# Altium schematic sheet Output_Terminals.SchDoc("Output terminals")
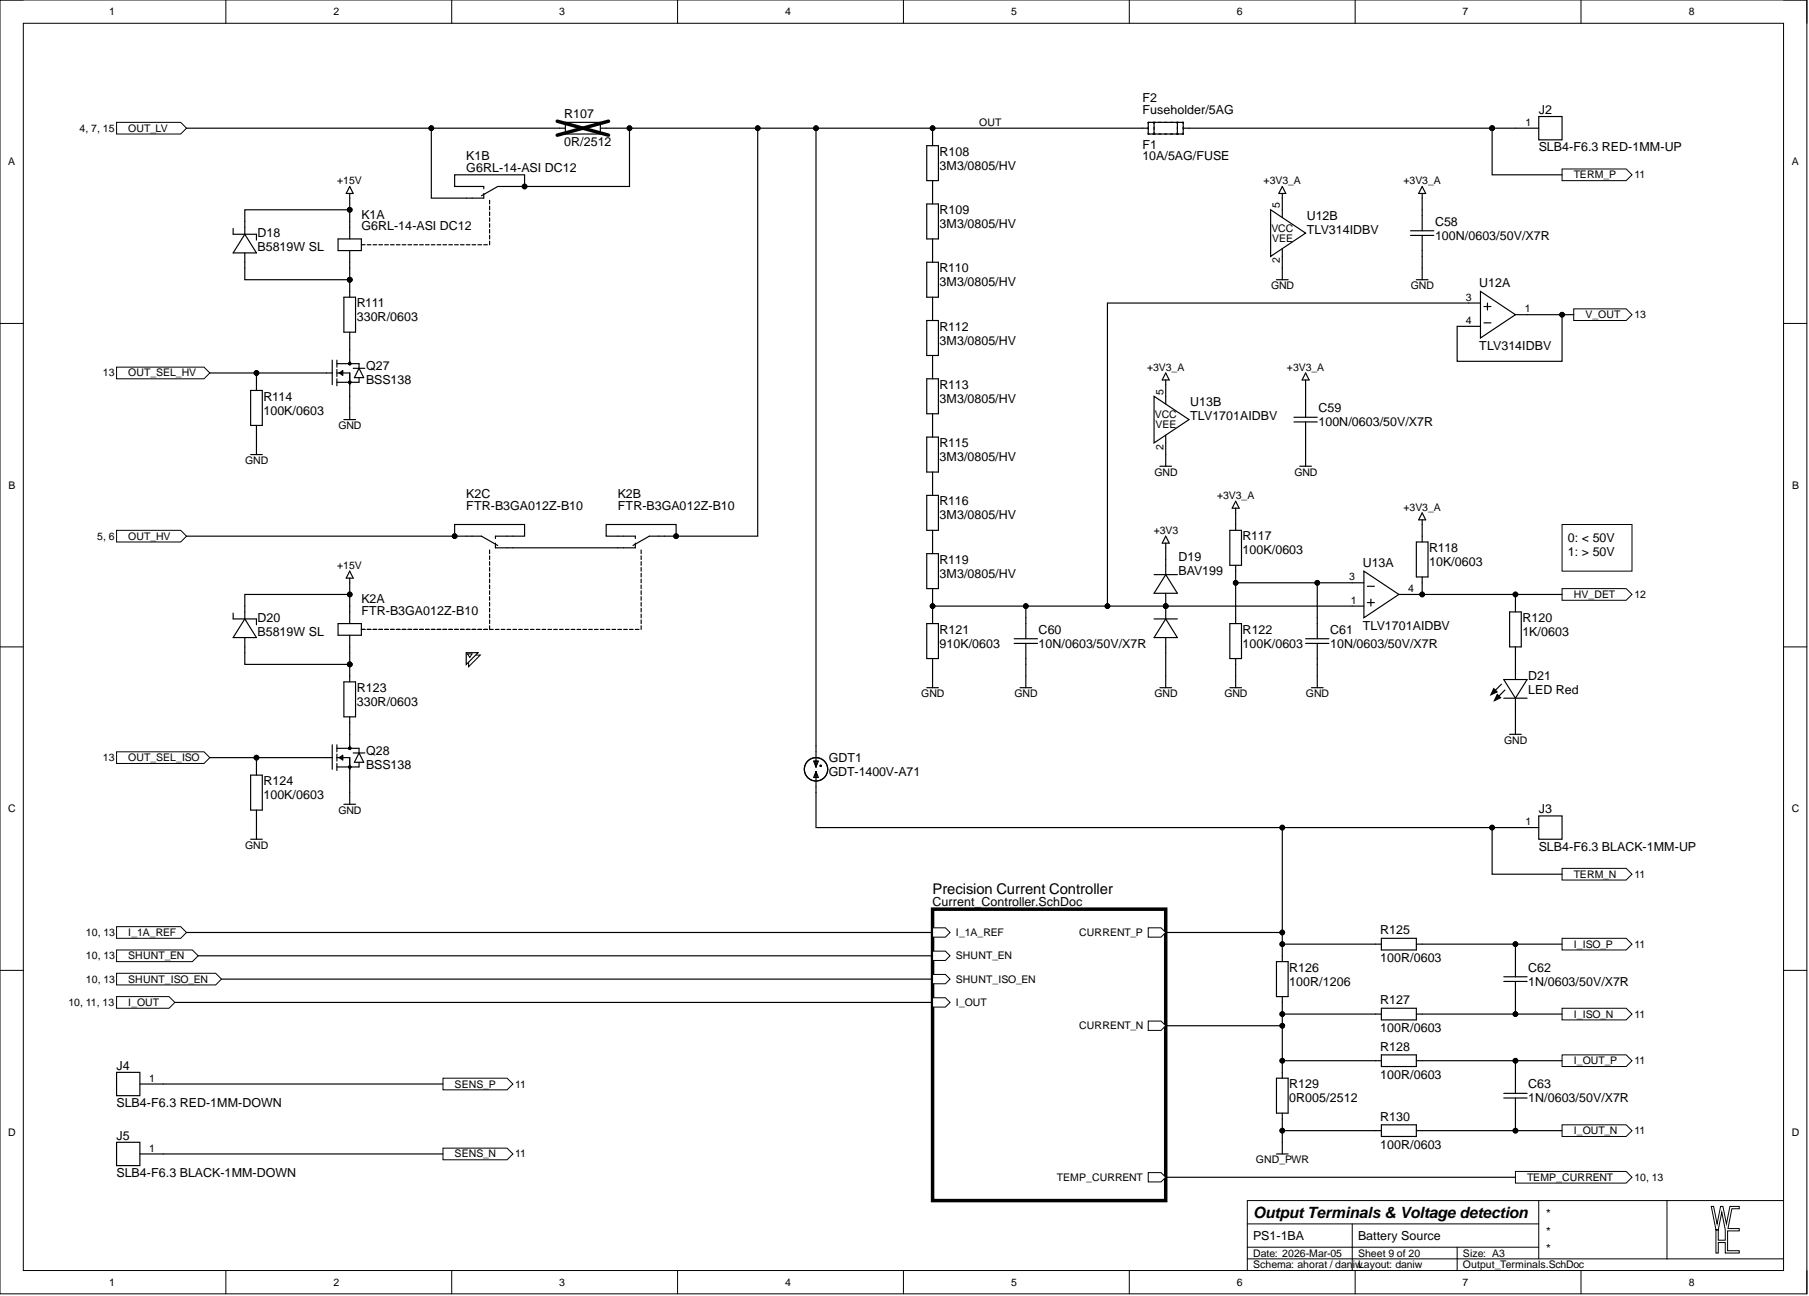
[diagram p0: Output_Terminals.SchDoc]

## Parts
C58  100N/0603/50V/X7R  [CAP0603_X7R]
C59  100N/0603/50V/X7R  [CAP0603_X7R]
C60  10N/0603/50V/X7R  [CAP0603_X7R]
C61  10N/0603/50V/X7R  [CAP0603_X7R]
C62  1N/0603/50V/X7R  [CAP0603_X7R]
C63  1N/0603/50V/X7R  [CAP0603_X7R]
D18  B5819W SL  [SOD3718X125]
D19  BAV199  [SOT2395P280X125-3-SE]
D20  B5819W SL  [SOD3718X125]
D21  LED Red  value Red  Absolute Value: Red  [LED0805_RED]
F1  10A/5AG/FUSE  [Fuse_5AG_5mm]
F2  Fuseholder/5AG  [KEYSTONE_3576]
GDT1  GDT-1400V-A71  [GDTAD1200W100L800D800H1200]
J2  SLB4-F6.3 RED-1MM-UP  [SLB4-F6.3-RED]
J3  SLB4-F6.3 BLACK-1MM-UP  [SLB4-F6.3-BLACK]
J4  SLB4-F6.3 RED-1MM-DOWN  [SLB4-F6.3-RED]
J5  SLB4-F6.3 BLACK-1MM-DOWN  [SLB4-F6.3-BLACK]
K1  G6RL-14-ASI DC12  [G6RL-1]  (parts A,B)
K2  FTR-B3GA012Z-B10  [FTR-B3G]  (parts A,B,C)
Q27  BSS138  [SOT2395P280X125-3-SE]
Q28  BSS138  [SOT2395P280X125-3-SE]
R107  0R/2512  [R2512-0.65-WW]
R108  3M3/0805/HV  [R0805-0.50-HV-WW]
R109  3M3/0805/HV  [R0805-0.50-HV-WW]
R110  3M3/0805/HV  [R0805-0.50-HV-WW]
R111  330R/0603  [R0603-0.40-WW]
R112  3M3/0805/HV  [R0805-0.50-HV-WW]
R113  3M3/0805/HV  [R0805-0.50-HV-WW]
R114  100K/0603  [R0603-0.40-WW]
R115  3M3/0805/HV  [R0805-0.50-HV-WW]
R116  3M3/0805/HV  [R0805-0.50-HV-WW]
R117  100K/0603  [R0603-0.40-WW]
R118  10K/0603  [R0603-0.40-WW]
R119  3M3/0805/HV  [R0805-0.50-HV-WW]
R120  1K/0603  [R0603-0.40-WW]
R121  910K/0603  [R0603-0.40-WW]
R122  100K/0603  [R0603-0.40-WW]
R123  330R/0603  [R0603-0.40-WW]
R124  100K/0603  [R0603-0.40-WW]
R125  100R/0603  [R0603-0.40-WW]
R126  100R/1206  [R1206-0.80-WW]
R126
R127  100R/0603  [R0603-0.40-WW]
R128  100R/0603  [R0603-0.40-WW]
R129  0R005/2512  [R2512-0.65-WW]
R130  100R/0603  [R0603-0.40-WW]
U12  TLV314IDBV  [SOT2395P280X125-5-NW]  (parts A,B)
U13  TLV1701AIDBV  [SOT2395P280X125-5-NW]  (parts A,B)

## Ports
HV_DET, I_1A_REF, I_ISO_N, I_ISO_P, I_OUT, I_OUT_N, I_OUT_P, OUT_HV, OUT_LV, OUT_SEL_HV, OUT_SEL_ISO, SENS_N, SENS_P, SHUNT_EN, SHUNT_ISO_EN, TEMP_CURRENT, TERM_N, TERM_P, V_OUT

## Nets
+3V3: D19.2
+3V3_A: C58.1 C59.1 R117.1 R118.1 U12.5 U13.5
+15V: D18.1 D20.1 K1.2 K2.2
GND: C58.2 C59.2 C60.2 C61.2 D19.1 D21.1 Q27.2 Q28.2 R114.2 R121.2 R122.2 R124.2 U12.2 U13.2
GND_PWR: R129.2 R130.1
HV_DET: R118.2 R120.2 U13.4 ; port HV_DET
I_ISO_N: C62.2 R127.2 ; port I_ISO_N
I_ISO_P: C62.1 R125.2 ; port I_ISO_P
I_OUT_N: C63.2 R130.2 ; port I_OUT_N
I_OUT_P: C63.1 R128.2 ; port I_OUT_P
OUT: F2.1 GDT1.1 K1.3 K1.5 K2.3 K2.5 R107.1 R108.1
OUT_HV: K2.6 K2.8 ; port OUT_HV
OUT_LV: K1.4 R107.2 ; port OUT_LV
OUT_SEL_HV: Q27.1 R114.1 ; port OUT_SEL_HV
OUT_SEL_ISO: Q28.1 R124.1 ; port OUT_SEL_ISO
SENS_N: J5.1 ; port SENS_N
SENS_P: J4.1 ; port SENS_P
TERM_N: GDT1.2 J3.1 R125.1 R126.1 ; port TERM_N
TERM_P: F2.2 J2.1 ; port TERM_P
V_OUT: U12.1 U12.4 ; port V_OUT
NetC60_1: C60.1 D19.3 R119.2 R121.1 U12.3 U13.1
NetC61_1: C61.1 R117.2 R122.1 U13.3
NetD18_2: D18.2 K1.1 R111.1
NetD20_2: D20.2 K2.1 R123.1
NetD21_2: D21.2 R120.1
NetK2_4: K2.4 K2.7
NetQ27_3: Q27.3 R111.2
NetQ28_3: Q28.3 R123.2
NetR108_2: R108.2 R109.1
NetR109_2: R109.2 R110.1
NetR110_2: R110.2 R112.1
NetR112_2: R112.2 R113.1
NetR113_2: R113.2 R115.1
NetR115_2: R115.2 R116.1
NetR116_2: R116.2 R119.1
NetR126_1: R126.1
NetR126_2: R126.2 R127.1 R128.1 R129.1
N00003: ; port SHUNT_EN
N00006: ; port TEMP_CURRENT
N00007: ; port SHUNT_ISO_EN
N00008: ; port I_OUT
N00009: ; port I_1A_REF

## Library data of the parts
C58 C59 = 100N/0603/50V/X7R: CAP SM 0603 100nF 50V X7R; Manufacturer: GENERIC; Manufacturer 1: YAGEO; Manufacturer Part Number 1: CC0603KPX7R9BB104; Absolute Value: 1E-7; Database Table Name: C$; Eurocircuits GPN: GPC0603104; Component Kind: Standard; HelpURL: https://www.yageo.com/upload/media/product/app/datasheet/mlcc/upy-gphc_x7r_6_3v-to-250v.pdf; JLC Type: Basic; Manufacturer 2: YAGEO; Manufacturer Part Number 2: CC0603MRX7R9BB104; Manufacturer Part Number 3: CC0603KRX7R9BB104; Package: 0603; Part Number: 100N/0603/50V/X7R; Supplier 1: Digi-Key; Supplier 2: Digi-Key; Supplier 3: LCSC; Supplier Part Number 1: 311-3567-1-ND; Supplier Part Number 2: 311-4110-1-ND; Supplier Part Number 3: C14663; Value: 100nF; Library Name: daniw_altium_lib.DbLib
C60 C61 = 10N/0603/50V/X7R: CAP SM 0603 10nF 50V X7R; Manufacturer: GENERIC; Manufacturer 1: YAGEO; Manufacturer Part Number 1: CC0603KPX7R9BB103; Absolute Value: 1E-8; Database Table Name: C$; Eurocircuits GPN: GPC0603103; Component Kind: Standard; HelpURL: https://www.yageo.com/upload/media/product/app/datasheet/mlcc/upy-gphc_x7r_6_3v-to-250v.pdf; Manufacturer 2: YAGEO; Manufacturer Part Number 2: CC0603KRX7R9BB103; Manufacturer Part Number 3: CC0603KRX7R9BB103; Package: 0603; Part Number: 10N/0603/50V/X7R; Supplier 1: Digi-Key; Supplier 2: Digi-Key; Supplier 3: LCSC; Supplier Part Number 1: 311-1572-1-ND; Supplier Part Number 2: 311-1085-1-ND; Supplier Part Number 3: C100042; Value: 10nF; Library Name: daniw_altium_lib.DbLib
C62 C63 = 1N/0603/50V/X7R: CAP SM 0603 1.0nF 50V X7R; Manufacturer: GENERIC; Manufacturer 1: YAGEO; Manufacturer Part Number 1: CC0603KPX7R9BB102; Absolute Value: 1E-9; Database Table Name: C$; Eurocircuits GPN: GPC0603102; Component Kind: Standard; HelpURL: https://www.yageo.com/upload/media/product/app/datasheet/mlcc/upy-gphc_x7r_6_3v-to-250v.pdf; JLC Type: Basic; Manufacturer 2: YAGEO; Manufacturer Part Number 2: CC0603KRX7R9BB102; Manufacturer Part Number 3: CL10B102KB8NNNC; Package: 0603; Part Number: 1N/0603/50V/X7R; Supplier 1: Digi-Key; Supplier 2: Digi-Key; Supplier 3: LCSC; Supplier Part Number 1: 13-CC0603KPX7R9BB102CT-ND; Supplier Part Number 2: 311-1080-1-ND; Supplier Part Number 3: C1588; Value: 1.0nF; Library Name: daniw_altium_lib.DbLib
D18 D20 = B5819W SL: 40V 600mV@1A 1A SOD-123 Schottky Barrier Diodes; Manufacturer: GENERIC; Manufacturer 1: SMC Diode Solutions; Database Table Name: D$; Absolute Value: 40V; Component Kind: Standard; HelpURL: https://datasheet.lcsc.com/lcsc/2304140030_Jiangsu-Changjing-Electronics-Technology-Co---Ltd--B5819W-SL_C8598.pdf; JLC Type: Basic; Manufacturer 3: Jiangsu Changjing Electronics Technology Co., Ltd.; Manufacturer Part Number 1: B5819WSL; Manufacturer Part Number 3: B5819W SL; Package: SOD-123; Part Number: B5819W SL; Supplier 1: Digikey; Supplier 3: LCSC; Supplier Part Number 1: 1655-B5819WSLCT-ND; Supplier Part Number 3: C8598; Value: B5819W SL; Library Name: daniw_altium_lib.DbLib
D19 = BAV199: Silicon Low Leakage Diode 85V; Manufacturer: Infineon Technologies; Manufacturer Part Number 1: BAV199E6433HTMA1; Database Table Name: D$; Absolute Value: 85V; Component Kind: Standard; HelpURL: https://www.infineon.com/dgdl/bav199series.pdf?folderId=db3a30431400ef6801141c748874044e&fileId=db3a30431400ef6801141cba733104e5; Manufacturer 1: Infineon Technologies; Manufacturer 3: LRC; Manufacturer Part Number 3: LBAV199LT1G; Package: SOT23; Part Number: BAV199; Supplier 1: Digikey; Supplier 3: LCSC; Supplier Part Number 1: BAV199E6433HTMA1CT-ND; Supplier Part Number 3: C12740; Value: BAV199; Library Name: daniw_altium_lib.DbLib
D21 = LED Red 0805: Generic LED Red 0805; Manufacturer 1: Würth; Manufacturer Part Number 1: 150080RS75000; Database Table Name: D$; Component Kind: Standard; HelpURL: https://www.we-online.com/katalog/datasheet/150060RS75000.pdf; JLC Type: Basic; Manufacturer: GENERIC; Manufacturer 3: Foshan NationStar Optoelectronics; Manufacturer Part Number 3: NCD0805R1; Package: 805; Part Number: LED Red 0805; Supplier 1: Digikey; Supplier 3: LCSC; Supplier Part Number 1: 732-4984-1-ND; Supplier Part Number 3: C84256; Library Name: daniw_altium_lib.DbLib
F1 = 10A/5AG/FUSE: Fuse 1000V AC/DC 10A 5AG 10.3x38mm; Manufacturer: Phoenix Contact; Manufacturer Part Number 1: 3061334; Database Table Name: R$; Component Kind: Standard; HelpURL: https://www.phoenixcontact.com/us/products/3061334/pdf; Manufacturer 1: Phoenix Contact; Package: 5AG; Part Number: 10A/5AG/FUSE; Supplier 1: Digi-Key; Supplier Part Number 1: 277-15212-ND; Value: 10A; Library Name: daniw_altium_lib.DbLib
F2 = Fuseholder/5AG: Manufacturer 1: Keystone Electronics; Manufacturer Part Number 1: 3576; Database Table Name: R$; Component Kind: Standard; HelpURL: https://www.keyelco.com/product-pdf.cfm?p=347; Manufacturer 2: Keystone Electronics; Manufacturer Part Number 2: 3576; Package: 5AG; Part Number: Fuseholder/5AG; Supplier 1: Digi-Key; Supplier 2: Mouser; Supplier Part Number 1: 36-3576-ND; Supplier Part Number 2: 534-3576; Library Name: daniw_altium_lib.DbLib
GDT1 = GDT-1400V-A71-12MM-4MM: 2-electrode surge arrester, 1400V, 10kA, A71 series, 12mm lead spacing, 4mm standoff height; Manufacturer: TDK; Manufacturer 1: EPCOS - TDK Electronics; Manufacturer Part Number 1: B88069X2180S102; Absolute Value: 1400; Database Table Name: GDT$; Component Kind: Standard; HelpURL: https://www.tdk-electronics.tdk.com/inf/100/ds/A71-H14X-X2180S102.pdf; Manufacturer 2: EPCOS - TDK Electronics; Manufacturer Part Number 2: B88069X3500T502; Package: Axial; Part Number: GDT-1400V-A71-12MM-4MM; Supplier 1: Digi-Key; Supplier 2: Digi-Key; Supplier Part Number 1: 495-4270-ND; Supplier Part Number 2: 495-5911-1-ND; Value: 1400V; Library Name: daniw_altium_lib.DbLib
J2 = SLB4-F6.3 RED-1MM-UP: SLB4-F6.3 Insulated, rigid, Ø 4 mm, accepting spring-loaded Ø 4 mm plugs with rigid insulating sleeve. Machined brass. Insulation material UL94 V-0. The socket is screwed into pre-drilled panels of plastic, metal, etc. Flat connecting tab 6.3 mm x 0.8 mm.; Manufacturer: Stäubli; Manufacturer 1: Stäubli Electrical Connectors; Manufacturer Part Number 1: 23.3060-22; Database Table Name: CON$; System: Connector; Component Kind: Standard; HelpURL: https://www.staubli.com/content/dam/ecs/technical-documentation/datasheets/TM/23.3060_en.pdf; Part Number: SLB4-F6.3 RED-1MM-UP; Supplier 1: Distrelec; Supplier Part Number 1: 30136218; Library Name: daniw_altium_lib.DbLib
J3 = SLB4-F6.3 BLACK-1MM-UP: SLB4-F6.3 Insulated, rigid, Ø 4 mm, accepting spring-loaded Ø 4 mm plugs with rigid insulating sleeve. Machined brass. Insulation material UL94 V-0. The socket is screwed into pre-drilled panels of plastic, metal, etc. Flat connecting tab 6.3 mm x 0.8 mm.; Manufacturer: Stäubli; Manufacturer 1: Stäubli Electrical Connectors; Manufacturer Part Number 1: 23.3060-21; Database Table Name: CON$; System: Connector; Component Kind: Standard; HelpURL: https://www.staubli.com/content/dam/ecs/technical-documentation/datasheets/TM/23.3060_en.pdf; Part Number: SLB4-F6.3 BLACK-1MM-UP; Supplier 1: Distrelec; Supplier Part Number 1: 30136217; Library Name: daniw_altium_lib.DbLib
J4 = SLB4-F6.3 RED-1MM-DOWN: SLB4-F6.3 Insulated, rigid, Ø 4 mm, accepting spring-loaded Ø 4 mm plugs with rigid insulating sleeve. Machined brass. Insulation material UL94 V-0. The socket is screwed into pre-drilled panels of plastic, metal, etc. Flat connecting tab 6.3 mm x 0.8 mm.; Manufacturer: Stäubli; Manufacturer 1: Stäubli Electrical Connectors; Manufacturer Part Number 1: 23.3060-22; Database Table Name: CON$; System: Connector; Component Kind: Standard; HelpURL: https://www.staubli.com/content/dam/ecs/technical-documentation/datasheets/TM/23.3060_en.pdf; Part Number: SLB4-F6.3 RED-1MM-DOWN; Supplier 1: Distrelec; Supplier Part Number 1: 30136218; Library Name: daniw_altium_lib.DbLib
J5 = SLB4-F6.3 BLACK-1MM-DOWN: SLB4-F6.3 Insulated, rigid, Ø 4 mm, accepting spring-loaded Ø 4 mm plugs with rigid insulating sleeve. Machined brass. Insulation material UL94 V-0. The socket is screwed into pre-drilled panels of plastic, metal, etc. Flat connecting tab 6.3 mm x 0.8 mm.; Manufacturer: Stäubli; Manufacturer 1: Stäubli Electrical Connectors; Manufacturer Part Number 1: 23.3060-21; Database Table Name: CON$; System: Connector; Component Kind: Standard; HelpURL: https://www.staubli.com/content/dam/ecs/technical-documentation/datasheets/TM/23.3060_en.pdf; Part Number: SLB4-F6.3 BLACK-1MM-DOWN; Supplier 1: Distrelec; Supplier Part Number 1: 30136217; Library Name: daniw_altium_lib.DbLib
K1 = G6RL-14-ASI DC12: RELAY GEN PURPOSE SPDT 8A 12V; Manufacturer: Omron Electronics Inc-EMC Div; Database Table Name: Misc$; Component Kind: Standard; HelpURL: https://omronfs.omron.com/en_US/ecb/products/pdf/en-g6rl.pdf; Manufacturer 1: Omron Electronics Inc-EMC Div; Manufacturer Part Number 1: G6RL-14-ASI DC12; Part Number: G6RL-14-ASI DC12; Supplier 1: Digi-Key; Supplier Part Number 1: 39-G6RL-14-ASIDC12-ND; Library Name: daniw_altium_lib.DbLib
K2 = FTR-B3GA012Z-B10: FTR-B3 Series Ultra Miniature Relay 2 Poles - 2 A (Low Profile Signal Relay); Manufacturer: Fujitsu; Database Table Name: Misc$; Component Kind: Standard; HelpURL: https://www.fujitsu.com/sg/imagesgig5/ftr-b3.pdf; JLC Type: Extended; Manufacturer 3: FUJITSU; Manufacturer Part Number 3: FTR-B3GA012Z-B10; Part Number: FTR-B3GA012Z-B10; Supplier 3: LCSC; Supplier Part Number 3: C2992429; Library Name: daniw_altium_lib.DbLib
Q27 Q28 = BSS138: N-Kanal 50 V 220mA (Ta) 350mW (Ta) Oberflächenmontage SOT-23; Manufacturer: GENERIC; Manufacturer 1: ANBON SEMICONDUCTOR (INT'L) LIMITED; Database Table Name: T$; Component Kind: Standard; HelpURL: https://mm.digikey.com/Volume0/opasdata/d220001/medias/docus/3037/BSS138.pdf; JLC Type: Extended; Manufacturer 2: FOSAN; Manufacturer 3: FOSAN; Manufacturer Part Number 1: BSS138; Manufacturer Part Number 2: BSS138; Manufacturer Part Number 3: BSS138; Package: SOT23; Part Number: BSS138; Supplier 1: Digi-Key; Supplier 2: LCSC; Supplier 3: LCSC; Supplier Part Number 1: 4530-BSS138CT-ND; Supplier Part Number 2: C2926186; Supplier Part Number 3: C2926186; Library Name: daniw_altium_lib.DbLib
R107 = 0R/2512: RES SM 2512 0R; Manufacturer: GENERIC; Absolute Value: 0; Database Table Name: R$; Component Kind: Standard; Package: 2512; Part Number: 0R/2512; Value: 0R; Library Name: daniw_altium_lib.DbLib
R108-R110 R112 R113 R115 R116 R119 = 3M3/0805/HV: RES SM 0805 3M3 High voltage; Manufacturer: GENERIC; Manufacturer 1: YAGEO; Manufacturer Part Number 1: RES SMD 3.3M OHM 1% 1/8W 0805; Absolute Value: 3300000; Database Table Name: R$; Component Kind: Standard; HelpURL: https://www.yageogroup.com/content/datasheet/asset/file/PYU-RV_51_ROHS_L; JLC Type: Extended; Manufacturer 3: YAGEO; Manufacturer Part Number 3: RV0805FR-073M3L; Package: 0805; Part Number: 3M3/0805/HV; Supplier 1: Digi-Key; Supplier 3: LCSC; Supplier Part Number 1: RV0805FR-073M3L-ND; Supplier Part Number 3: C873387; Value: 3M3; Library Name: daniw_altium_lib.DbLib
R111 R123 = 330R/0603: RES SM 0603 330R; Manufacturer: GENERIC; Manufacturer 1: YAGEO; Manufacturer Part Number 1: RC0603FR-13330RL; Absolute Value: 330; Database Table Name: R$; Eurocircuits GPN: GPR0603330R; Component Kind: Standard; HelpURL: https://www.yageogroup.com/content/datasheet/asset/file/PYU-RC_GROUP_51_ROHS_L; JLC Type: Basic; Manufacturer 2: YAGEO; Manufacturer 3: UNI-ROYAL(Uniroyal Elec); Manufacturer Part Number 2: RC0603FR-10330RL; Manufacturer Part Number 3: 0603WAF3300T5E; Package: 0603; Part Number: 330R/0603; Supplier 1: Digi-Key; Supplier 2: Digi-Key; Supplier 3: LCSC; Supplier Part Number 1: 13-RC0603FR-13330RLCT-ND; Supplier Part Number 2: 13-RC0603FR-10330RLCT-ND; Supplier Part Number 3: C23138; Value: 330R; Library Name: daniw_altium_lib.DbLib
R114 R117 R122 R124 = 100K/0603: RES SM 0603 100K; Manufacturer: GENERIC; Manufacturer 1: YAGEO; Manufacturer Part Number 1: RC0603FR-13100KL; Absolute Value: 100000; Database Table Name: R$; Eurocircuits GPN: GPR0603100K; Component Kind: Standard; HelpURL: https://www.yageogroup.com/content/datasheet/asset/file/PYU-RC_GROUP_51_ROHS_L; JLC Type: Basic; Manufacturer 2: YAGEO; Manufacturer 3: UNI-ROYAL(Uniroyal Elec); Manufacturer Part Number 2: RC0603FR-10100KL; Manufacturer Part Number 3: 0603WAF1003T5E; Package: 0603; Part Number: 100K/0603; Supplier 1: Digi-Key; Supplier 2: Digi-Key; Supplier 3: LCSC; Supplier Part Number 1: 13-RC0603FR-13100KLCT-ND; Supplier Part Number 2: 13-RC0603FR-10100KLCT-ND; Supplier Part Number 3: C25803; Value: 100K; Library Name: daniw_altium_lib.DbLib
R118 = 10K/0603: RES SM 0603 10K; Manufacturer: GENERIC; Manufacturer 1: YAGEO; Manufacturer Part Number 1: RC0603FR-1310KL; Absolute Value: 10000; Database Table Name: R$; Eurocircuits GPN: GPR060310K; Component Kind: Standard; HelpURL: https://www.yageogroup.com/content/datasheet/asset/file/PYU-RC_GROUP_51_ROHS_L; JLC Type: Basic; Manufacturer 2: YAGEO; Manufacturer 3: UNI-ROYAL(Uniroyal Elec); Manufacturer Part Number 2: RC0603FR-1010KL; Manufacturer Part Number 3: 0603WAF1002T5E; Package: 0603; Part Number: 10K/0603; Supplier 1: Digi-Key; Supplier 2: Digi-Key; Supplier 3: LCSC; Supplier Part Number 1: 13-RC0603FR-1310KLCT-ND; Supplier Part Number 2: 13-RC0603FR-1010KLCT-ND; Supplier Part Number 3: C25804; Value: 10K; Library Name: daniw_altium_lib.DbLib
R120 = 1K/0603: RES SM 0603 1K; Manufacturer: GENERIC; Manufacturer 1: YAGEO; Manufacturer Part Number 1: RC0603FR-131KL; Absolute Value: 1000; Database Table Name: R$; Eurocircuits GPN: GPR06031K; Component Kind: Standard; HelpURL: https://www.yageogroup.com/content/datasheet/asset/file/PYU-RC_GROUP_51_ROHS_L; JLC Type: Basic; Manufacturer 2: YAGEO; Manufacturer 3: UNI-ROYAL(Uniroyal Elec); Manufacturer Part Number 2: RC0603FR-101KL; Manufacturer Part Number 3: 0603WAF1001T5E; Package: 0603; Part Number: 1K/0603; Supplier 1: Digi-Key; Supplier 2: Digi-Key; Supplier 3: LCSC; Supplier Part Number 1: 13-RC0603FR-131KLCT-ND; Supplier Part Number 2: 13-RC0603FR-101KLCT-ND; Supplier Part Number 3: C21190; Value: 1K; Library Name: daniw_altium_lib.DbLib
R121 = 910K/0603: RES SM 0603 910K; Manufacturer: GENERIC; Manufacturer 1: YAGEO; Manufacturer Part Number 1: RC0603FR-07910KL; Absolute Value: 910000; Database Table Name: R$; Eurocircuits GPN: GPR0603910K; Component Kind: Standard; HelpURL: https://www.yageogroup.com/content/datasheet/asset/file/PYU-RC_GROUP_51_ROHS_L; JLC Type: Extended; Manufacturer 3: YAGEO; Manufacturer Part Number 3: RC0603FR-07910KL; Package: 0603; Part Number: 910K/0603; Supplier 1: Digi-Key; Supplier 3: LCSC; Supplier Part Number 1: 311-910KHRCT-ND; Supplier Part Number 3: C137672; Value: 910K; Library Name: daniw_altium_lib.DbLib
R125 R127 R128 R130 = 100R/0603: RES SM 0603 100R; Manufacturer: GENERIC; Manufacturer 1: YAGEO; Manufacturer Part Number 1: RC0603FR-13100RL; Absolute Value: 100; Database Table Name: R$; Eurocircuits GPN: GPR0603100R; Component Kind: Standard; HelpURL: https://www.yageogroup.com/content/datasheet/asset/file/PYU-RC_GROUP_51_ROHS_L; Manufacturer 2: YAGEO; Manufacturer 3: UNI-ROYAL(Uniroyal Elec); Manufacturer Part Number 2: RC0603FR-10100RL; Manufacturer Part Number 3: 0603WAF1000T5E; Package: 0603; Part Number: 100R/0603; Supplier 1: Digi-Key; Supplier 2: Digi-Key; Supplier 3: LCSC; Supplier Part Number 1: 13-RC0603FR-13100RLCT-ND; Supplier Part Number 2: 13-RC0603FR-10100RLCT-ND; Supplier Part Number 3: C22775; Value: 100R; Library Name: daniw_altium_lib.DbLib
R126 = 100R/1206: RES SM 1206 100R; Manufacturer: GENERIC; Manufacturer 1: YAGEO; Manufacturer Part Number 1: RC1206FR-07100RL; Absolute Value: 100; Database Table Name: R$; Component Kind: Standard; HelpURL: https://www.yageogroup.com/content/datasheet/asset/file/PYU-RC_GROUP_51_ROHS_L; Manufacturer 3: UNI-ROYAL(Uniroyal Elec); Manufacturer Part Number 3: 1206W4F1000T5E; Package: 1206; Part Number: 100R/1206; Supplier 1: Digi-Key; Supplier 3: LCSC; Supplier Part Number 1: 311-100FRCT-ND; Supplier Part Number 3: C17901; Value: 100R; Library Name: daniw_altium_lib.DbLib
R129 = 0R005/2512: RES SM 2512 0R005; Manufacturer: GENERIC; Manufacturer 1: Ohmite; Manufacturer Part Number 1: MCS3264R005FER; Absolute Value: 0.005; Database Table Name: R$; Component Kind: Standard; HelpURL: https://www.ohmite.com/assets/docs/res_mcs.pdf?r=false; Manufacturer 3: prosemi; Manufacturer Part Number 3: LMP25MF2P0R005; Package: 2512; Part Number: 0R005/2512; Supplier 1: Digi-Key; Supplier 3: LCSC; Supplier Part Number 1: MCS3264R005FERCT-ND; Supplier Part Number 3: C2937402; Value: 0R005; Library Name: daniw_altium_lib.DbLib
U12 = TLV314 SOT23: TLV314, 3-MHz, Low-Power, Internal EMI Filter, RRIO, Operational Amplifier; Manufacturer: Texas Instruments; Manufacturer Part Number 1: TLV314IDBVR; Database Table Name: Integrated$; Component Kind: Standard; HelpURL: https://www.ti.com/lit/ds/symlink/tlv4314.pdf; Manufacturer 1: Texas Instruments; Manufacturer 2: Texas Instruments; Manufacturer 3: Texas Instruments; Manufacturer Part Number 2: TLV314IDBVT; Manufacturer Part Number 3: TLV314IDBVR; Package: SOT23-5; Part Number: TLV314 SOT23; Supplier 1: Digi-Key; Supplier 2: Digi-Key; Supplier 3: LCSC; Supplier Part Number 1: 296-46275-1-ND; Supplier Part Number 2: 296-44110-2-ND; Supplier Part Number 3: C133032; Library Name: daniw_altium_lib.DbLib
U13 = TLV1701 SOT23: TLV1701 2.2-V to 36-V, microPower Comparato; Manufacturer: Texas Instruments; Manufacturer Part Number 1: TLV1701AIDBVR; Database Table Name: Integrated$; Component Kind: Standard; HelpURL: https://www.ti.com/lit/ds/symlink/tlv1701.pdf; Manufacturer 1: Texas Instruments; Manufacturer 2: Texas Instruments; Manufacturer 3: Texas Instruments; Manufacturer Part Number 2: TLV1701QDBVRQ1; Manufacturer Part Number 3: TLV1701AIDBVR; Package: SOT23-5; Part Number: TLV1701 SOT23; Supplier 1: Digi-Key; Supplier 2: Digi-Key; Supplier 3: LCSC; Supplier Part Number 1: 296-40599-1-ND; Supplier Part Number 2: 296-47458-1-ND; Supplier Part Number 3: C130035; Library Name: daniw_altium_lib.DbLib
